# Altium schematic sheet nRF24L01.SchDoc
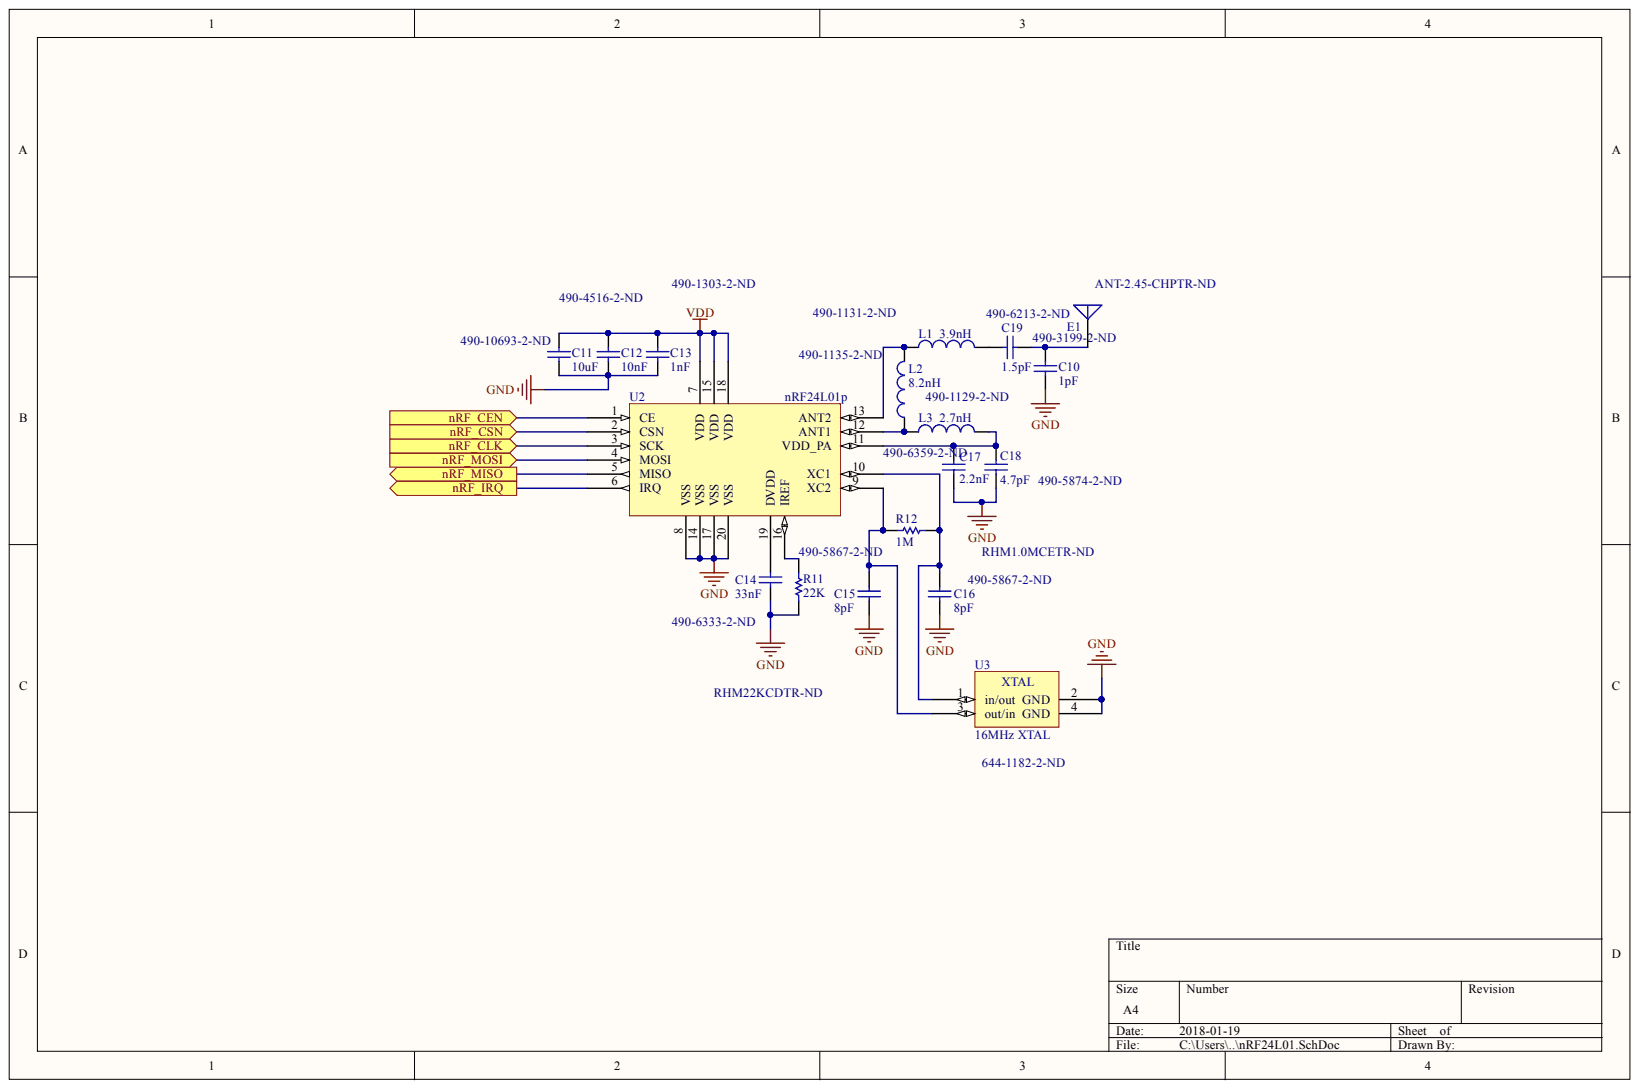
[diagram p0: nRF24L01.SchDoc]

## Parts
C10  value 1pF  Supplier Part Number 1: 490-3199-2-ND  [0402]
C11  value 10uF  Supplier Part Number 1: 490-10693-2-ND  [0402]
C12  value 10nF  [0402]
C13  value 1nF  [0402]
C14  value 33nF  Supplier Part Number 1: 490-6333-2-ND  [0402]
C15  value 8pF  [0402]
C16  value 8pF  Supplier Part Number 1: 490-5867-2-ND  [0402]
C17  value 2.2nF  Supplier Part Number 1: 490-6359-2-ND  [0402]
C18  value 4.7pF  [0402]
C19  value 1.5pF  Supplier Part Number 1: 490-6213-2-ND  [0402]
E1  Supplier Part Number 1: ANT-2.45-CHPTR-ND  [ANT-2.45-CHP-B]
L1  value 3.9nH  Supplier Part Number 1: 490-1131-2-ND  [0402]
L2  value 8.2nH  [0402]
L3  value 2.7nH  Supplier Part Number 1: 490-1129-2-ND  [0402]
R11  value 22K  [0402]
R12  value 1M  [0402]
U2  nRF24L01p  [Component_nRF]
U3  16MHz XTAL  [8Y-16.000MAAV-T]

## Ports
NRF_CEN, NRF_CLK, NRF_CSN, NRF_IRQ, NRF_MISO, NRF_MOSI

## Nets
GND: C10.2 C11.1 C12.1 C13.1 C14.1 C15.1 C16.1 C17.1 C18.1 R11.1 U2.8(VSS) U2.14(VSS) U2.17(VSS) U2.20(VSS) U3.2(GND) U3.4(GND)
VDD: C11.2 C12.2 C13.2 U2.7(VDD) U2.15(VDD) U2.18(VDD)
NetC10_1: C10.1 C19.1 E1.1
NetC14_2: C14.2 U2.19(DVDD)
NetC15_2: C15.2 R12.2 U2.9(XC2) U3.3(out/in)
NetC16_2: C16.2 R12.1 U2.10(XC1) U3.1(in/out)
NetC17_2: C17.2 C18.2 L3.2 U2.11(VDD_PA)
NetC19_2: C19.2 L1.2
NetL1_1: L1.1 L2.2 U2.13(ANT2)
NetL2_1: L2.1 L3.1 U2.12(ANT1)
NetR11_2: R11.2 U2.16(IREF)
NetU2_1: U2.1(CE) ; port NRF_CEN
NetU2_2: U2.2(CSN) ; port NRF_CSN
NetU2_3: U2.3(SCK) ; port NRF_CLK
NetU2_4: U2.4(MOSI) ; port NRF_MOSI
NetU2_5: U2.5(MISO) ; port NRF_MISO
NetU2_6: U2.6(IRQ) ; port NRF_IRQ

## Library data of the parts
C10-C19 = Cap Semi: Capacitor (Semiconductor SIM Model); Component Kind: Standard; LatestRevisionDate: 29-May-2009; LatestRevisionNote: IPC-7351 Footprint Added.; Library Name: Miscellaneous Devices.IntLib; PackageDescription: Chip Capacitor; PackageReference: C1206; Published: 8-Jun-2000; Signal Integrity: Cap Semi; Simulation: CAP; Supplier 1: Digi-Key; Publisher: Altium Limited
  C12: Supplier Part Number 1: 490-4516-2-ND
  C13: Supplier Part Number 1: 490-1303-2-ND
  C15: Supplier Part Number 1: 490-5867-2-ND
  C18: Supplier Part Number 1: 490-5874-2-ND
E1 = Antenna: Generic Antenna; Component Kind: Standard; LatestRevisionDate: 17-Jul-2002; LatestRevisionNote: Re-released for DXP Platform.; Library Name: Miscellaneous Devices.IntLib; PackageReference: PIN1; Published: 24-Mar-1999; Supplier 1: Digi-Key; Publisher: Altium Limited
L1-L3 = Inductor: Code_IEC: 1005; Code_JEITA: 0402; Component Kind: Standard; Description: Inductor; LatestRevisionDate: 29-May-2009; LatestRevisionNote: IPC-7351 Footprint Added.; Library Name: Miscellaneous Devices.IntLib; PackageDescription: Chip Inductor; PackageReference: 0402-A; Published: 8-Jun-2000; Signal Integrity: Inductor; Simulation: INDUCTOR; Supplier 1: Digi-Key; Publisher: Altium Limited
  L2: Supplier Part Number 1: 490-1135-2-ND
R11 R12 = Res Semi: Semiconductor Resistor; Component Kind: Standard; LatestRevisionDate: 17-Jul-2002; LatestRevisionNote: Re-released for DXP Platform.; Library Name: Miscellaneous Devices.IntLib; PackageDescription: Axial Device, Thru-Hole; 2 Leads; 0.5 in Pin Spacing; PackageReference: AXIAL-0.5; Published: 8-Jun-2000; Signal Integrity: Res Semi; Simulation: RES; Supplier 1: Digi-Key; Publisher: Altium Limited
  R11: Supplier Part Number 1: RHM22KCDTR-ND
  R12: Supplier Part Number 1: RHM1.0MCETR-ND
U2 = Component_nRF: Component Kind: Standard; Library Name: nRF24L01.SchLib
U3 = 8Y-16.000MAAV-T: SMD 16 MHz XTAL; Component Kind: Standard; Supplier 1: Digi-Key; Supplier Part Number 1: 644-1182-2-ND; Library Name: headstage_parts.SchLib
